# Altium schematic sheet Full_Bridge.SchDoc(Full_Bridge)
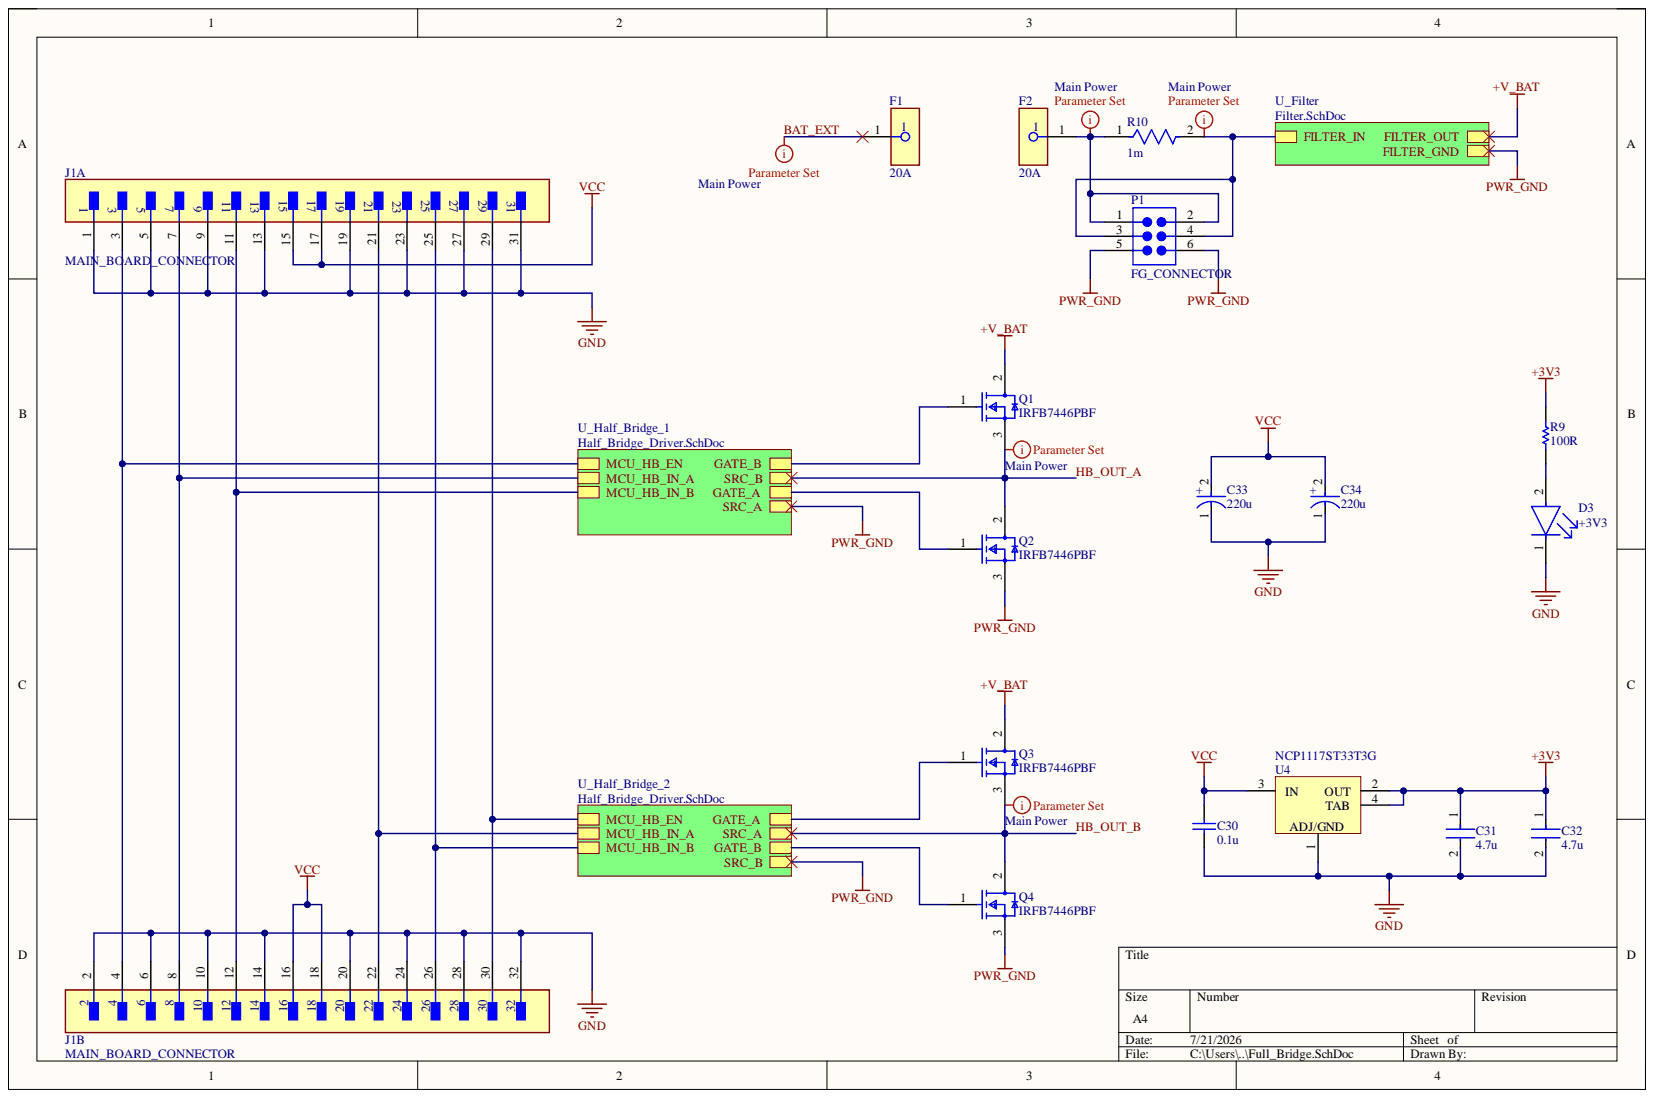
[diagram p0: Full_Bridge.SchDoc]

## Parts
C30  0.1u  [CAPC2013X145X48NL20T23]
C31  4.7u  [FP-GRM31C-0_2-e0_3_0_8-IPC_C]
C32  4.7u  [FP-GRM31C-0_2-e0_3_0_8-IPC_C]
C33  220u  [FP-RAD-TH-D_5_0_5-L_7_1-F-MFG]
C34  220u  [FP-RAD-TH-D_5_0_5-L_7_1-F-MFG]
D3  +3V3  [FP-LTST-C171KGKT-MFG]
F1  20A  [FP-8040_0001-MFG]
F2  20A  [FP-8040_0001-MFG]
J1  MAIN_BOARD_CONNECTOR  [FP-MTSW-116-22-G-D-315-MFG]  (parts A,B)
P1  FG_CONNECTOR  [SMTC-MTSW-103-07-X-D-244]
Q1  IRFB7446PBF  [FP-PG-TO220-3-MFG]
Q2  IRFB7446PBF  [FP-PG-TO220-3-MFG]
Q3  IRFB7446PBF  [FP-PG-TO220-3-MFG]
Q4  IRFB7446PBF  [FP-PG-TO220-3-MFG]
R9  100R  [RESC2013X60X35ML10T20]
R10  1m  [FP-WSLF2512-0_43-MFG]
U4  NCP1117ST33T3G  [ONSC-SOT-223-4-318H-01_A_V]

## Nets
+3V3: C31.1 C32.1 R9.2 U4.2(OUT) U4.4(TAB)
+V_BAT: Q1.2 Q3.2
GND: C30.1 C31.2 C32.2 C33.1 C34.1 D3.1 J1.1 J1.2 J1.5 J1.6 J1.9 J1.10 J1.13 J1.14 J1.19 J1.20 J1.23 J1.24 J1.27 J1.28 J1.31 J1.32 U4.1
PWR_GND: P1.5 P1.6 Q2.3 Q4.3
VCC: C30.2 C33.2 C34.2 J1.15 J1.16 J1.17 J1.18 U4.3(IN)
BAT_EXT: F1.1
HB_OUT_A: Q1.3 Q2.2
HB_OUT_B: Q3.3 Q4.2
NetD3_2: D3.2 R9.1
NetF2_1: F2.1 P1.1 P1.2 R10.1
NetJ1_3: J1.3 J1.4
NetJ1_7: J1.7 J1.8
NetJ1_11: J1.11 J1.12
NetJ1_21: J1.21 J1.22
NetJ1_25: J1.25 J1.26
NetJ1_29: J1.29 J1.30
NetP1_3: P1.3 P1.4 R10.2
NetQ1_1: Q1.1
NetQ2_1: Q2.1
NetQ3_1: Q3.1
NetQ4_1: Q4.1

## Library data of the parts
C30 = CMP-2007-01705-1: Manufacturer: Vitramon; Part Number: VJ0805Y104KXAMR; Component Kind: Standard; Library Name: Altium Content Vault
C31 C32 = CMP-06035-057352-1: Chip Multilayer Ceramic Capacitors for General Purpose, 1206, 4.7uF, X7R, 15%, 20%, 50V; Capacitance: 4.7uF; Case Code (Imperial): 1206; Case Code (Metric): 3216; Case/Package: 1206; Component Kind: Standard; Depth: 1.6mm; Dielectric: X7R; Height: 1.6mm; Lead Free: Lead Free; Length: 3.2mm; Library Name: Altium Content Vault; Max Operating Temperature: 125°C; Min Operating Temperature: -55°C; Mount: Surface Mount; Package Quantity: 2000; Packaging: Tape and Reel; Radiation Hardening: No; Termination: SMD/SMT; Thickness: 0.071inch; Tolerance: 20%; Voltage Rating: 50V; Voltage Rating (DC): 50V; Width: 0.063inch
C33 C34 = CMP-05427-001207-1: Aluminum Electrolytic Capacitors (Radial Lead Type) 22uF ±20% 25V; Life (Hours): 1000Hour; Ripple Current: 55mA; Capacitance: 22uF; Case/Package: Radial; Component Kind: Standard; Diameter: 5mm; Height: 7mm; Height - Seated (Max): 0.315inch; Lead Pitch: 2.5mm; Length: 7mm; Library Name: Altium Content Vault; Max Operating Temperature: 85°C; Min Operating Temperature: -40°C; Mount: Through Hole; Package Quantity: 2000; Packaging: Ammo Pack; Radiation Hardening: No; RoHS Compliant: Yes; Series: KA; Termination: Radial; Tolerance: 20%; Voltage Rating: 25V; Voltage Rating (DC): 25V
D3 = CMP-22017-000037-1: LED GREEN CLEAR SMD; Dominant Wavelength: 571nm; Forward Current: 30mA; Forward Voltage: 2.4V; Lens Color: Colorless; Lens Style: Rectangular; Luminous Intensity: 35mcd; Number of Elements: 1; Power Dissipation: 75mW; Reverse Voltage: 5V; Test Current: 20mA; Viewing Angle: 130°; Wavelength: 574nm; Case Code (Imperial): 0805; Case Code (Metric): 2012; Case/Package: 0805; Color: Green; Component Kind: Standard; Depth: 1.25mm; Height: 0.8mm; Illumination Color: Green; Lead Free: Lead Free; Length: 2mm; Lens Transparency: Clear; Library Name: Altium Content Vault; Max Operating Temperature: 85°C; Min Operating Temperature: -55°C; Mount: Surface Mount; Number of LEDs: 1; Number of Pins: 2; Package Quantity: 1; Packaging: Tape and Reel; Power Rating: 75mW; Radiation Hardening: No; Width: 1.25mm
F1 F2 = CMP-26292-000011-1: FUSE CLIP CARTRIDGE 600V 32A PCB; Color: Silver; Fuse Size: 1/4" Dia x 1-1/4" L (6.35mm x 31.8mm); Material: Copper; Number of Circuits: 1; Component Kind: Standard; Contact Material: Copper; Contact Plating: Tin; Current Rating: 32A; Depth: 8.2mm; Fuse Current: 32A; Height: 14.3mm; Length: 8mm; Library Name: Altium Content Vault; Max Operating Temperature: 125°C; Min Operating Temperature: -55°C; Mount: PCB; Orientation: Horizontal; Package Quantity: 1000; Packaging: Bulk; Radiation Hardening: No; REACH SVHC: No SVHC; Series: CQP; Termination: PC Pin; Voltage: 600V; Voltage Rating (AC): 600V; Voltage Rating (DC): 600V; Weight: 0.070548oz; Width: 7.2mm
J1 = CMP-02766-000676-1: CONN HEADER VERT 32POS 2.54MM; Component Kind: Standard; Contact Material: Bronze; Contact Plating: Gold; Lead Free: Lead Free; Library Name: Altium Content Vault; Number of Rows: 2
P1 = CMP-1024-00096-1: Modified .025" SQ Post Header, Through-hole, Vertical, -55 to 125 degC, 2.54 mm Pitch, 6-Pin, Male, RoHS; Manufacturer: Samtec; PartNumber: MTSW-103-07-G-D-244; Pitch: 2.54 mm; Component Kind: Standard; ComponentLink1Description: Manufacturer URL; ComponentLink1URL: http://www.samtec.com; ComponentLink2Description: Datasheet; ComponentLink2URL: http://www.samtec.com/documents/webfiles/pdf/MTSW.PDF; ComponentLink3Description: Package Specification; ComponentLink3URL: http://www.samtec.com/documents/webfiles/cpdf/MTSW-XXX-XX-X-X-XXXX-XX-XXX-MKT1.pdf; DatasheetVersion: F-214; Library Name: Altium Content Vault; Mounting Technology: Through-hole; Orientation: Vertical; PackageDescription: Assembly .025" [.64] SQ Post Terminal Strip; PackageReference: MTSW-103-07-X-D-244; PackageVersion: BI, 2/1987; RoHS: True; Temperature Range: -55 to 125 degC
Q1-Q4 = CMP-32901-000256-1: MOSFET N-CH 40V 120A TO220AB; Input Capacitance: 4.73nF; Max Power Dissipation: 143W; Rds On Max: 2.5mR; Threshold Voltage: 3V; Case/Package: TO-220; Component Kind: Standard; Continuous Drain Current (ID): 120A; Drain to Source Voltage (Vdss): 40V; Halogen Free: Halogen Free; Lead Free: Lead Free; Library Name: Altium Content Vault; Max Dual Supply Voltage: 40V; Max Operating Temperature: 175°C; Min Operating Temperature: -55°C; Mount: Through Hole; Number of Pins: 3; Packaging: Rail/Tube; REACH SVHC: No SVHC; RoHS Compliant: Yes
R9 = CMP-2100-03669-1: Chip Resistor, 100 Ohm, +/-1%, 0.125 W, -55 to 155 degC, 0805 (2012 Metric), RoHS, Tape and Reel; Manufacturer: Yageo; Max Power Dissipation: 125mW; Resistance: 100Ohm; Temperature Coefficient: 100ppm/degreesC; Tolerance: 1%; Voltage Rating: 150V; Case Code (Imperial): 0805; Case Code (Metric): 2012; Case/Package: 0805; Component Kind: Standard; Composition: ThickFilm; Datasheet URL: http://www.yageo.com/NewPortal/yageodocoutput?fileName=/pdf/R-Chip/PYu-RC_Group_51_RoHS_L_5.pdf; Datasheet Version: Rev. 5, 10/2016; Depth: 1.25mm; Height: 0.024inch; Lead Free: LeadFree; Lead Pitch: 0.4mm; Length: 2mm; Library Name: Altium Content Vault; Manufacturer URL: http://www.yageo.com/; Max Operating Temperature: 155degC; Military Standard: Not; Min Operating Temperature: -55degC; Number of Pins: 2; Number of Terminations: 2; Package Description: 2-Pin Surface Mounted Device, Body 2 x 1.25 mm; Package Quantity: 1; Package Reference: 0805; Packaging: TapeandReel; Power Rating: 125mW; REACH SVHC: Unknown; RoHSCompliant: true; Termination: SMD/SMT; Voltage Rating (DC): 150V; Width: 0.049inch
R10 = CMP-02407-013110-1: RES 0.001 OHM 1% 5W 2512; Resistance: 1mR; Temperature Coefficient: 170ppm/°C; Case Code (Imperial): 2512; Case Code (Metric): 6432; Case/Package: 2512; Component Kind: Standard; Features: Moisture Resistant; Height: 0.024inch; Lead Free: Lead Free; Length: 0.25inch; Library Name: Altium Content Vault; Max Operating Temperature: 170°C; Max Power Dissipation: 5W; Min Operating Temperature: -65°C; Number of Terminations: 2; Packaging: Cut Tape; Ratings: AEC-Q200; Resistor Type: Metal Element; RoHS Compliant: Yes; Series: WSLF; Tolerance: 1%; Width: 0.125inch
U4 = CMP-1679-00010-1: Low-Dropout Positive Fixed Voltage Regulator, 1 A, 3.3 V Output Voltage, 0 to 125 degC, 4-Pin SOT-223 (318H-01), Pb-Free, Tape and Reel; Case\Package: 0603; Power Rating: 100 mW; Resistance: 220 kohm; Tolerance: 1%; Type (Resistor): Thick Film; Voltage Rating: 75 V; Case Code (Imperial): 0603; Case Code (Metric): 1608; Component Kind: Standard; ComponentLink1Description: Manufacturer URL; ComponentLink1URL: http://www.onsemi.com/; ComponentLink2Description: Datasheet; ComponentLink2URL: http://www.onsemi.com/pub_link/Collateral/NCP1117-D.PDF; DatasheetVersion: Rev. 27, 09/2014; Height: 0.45 mm; Length: 1.55 mm; Library Name: Altium Content Vault; Max Operating Temperature: 155 degC; Min Operating Temperature: -55 degC; Mount: Surface Mount; Package Quantity: 5000; PackageDescription: 4-Pin Small Outline Transistor, Body 6.5 x 3.5 mm, Pitch 2.3 mm; PackageReference: SOT-223-4-318H-01_A; Packaging: Tape and Reel; Radiation Hardening: No; REACH SVHC: Unknown; RoHSCompliant: true; Weight: 7.1E-05 oz; Width: 0.85 mm
